# Altium schematic sheet eboardPapyFeederV2.1.SchDoc(eboardPapyFeederV2.1)
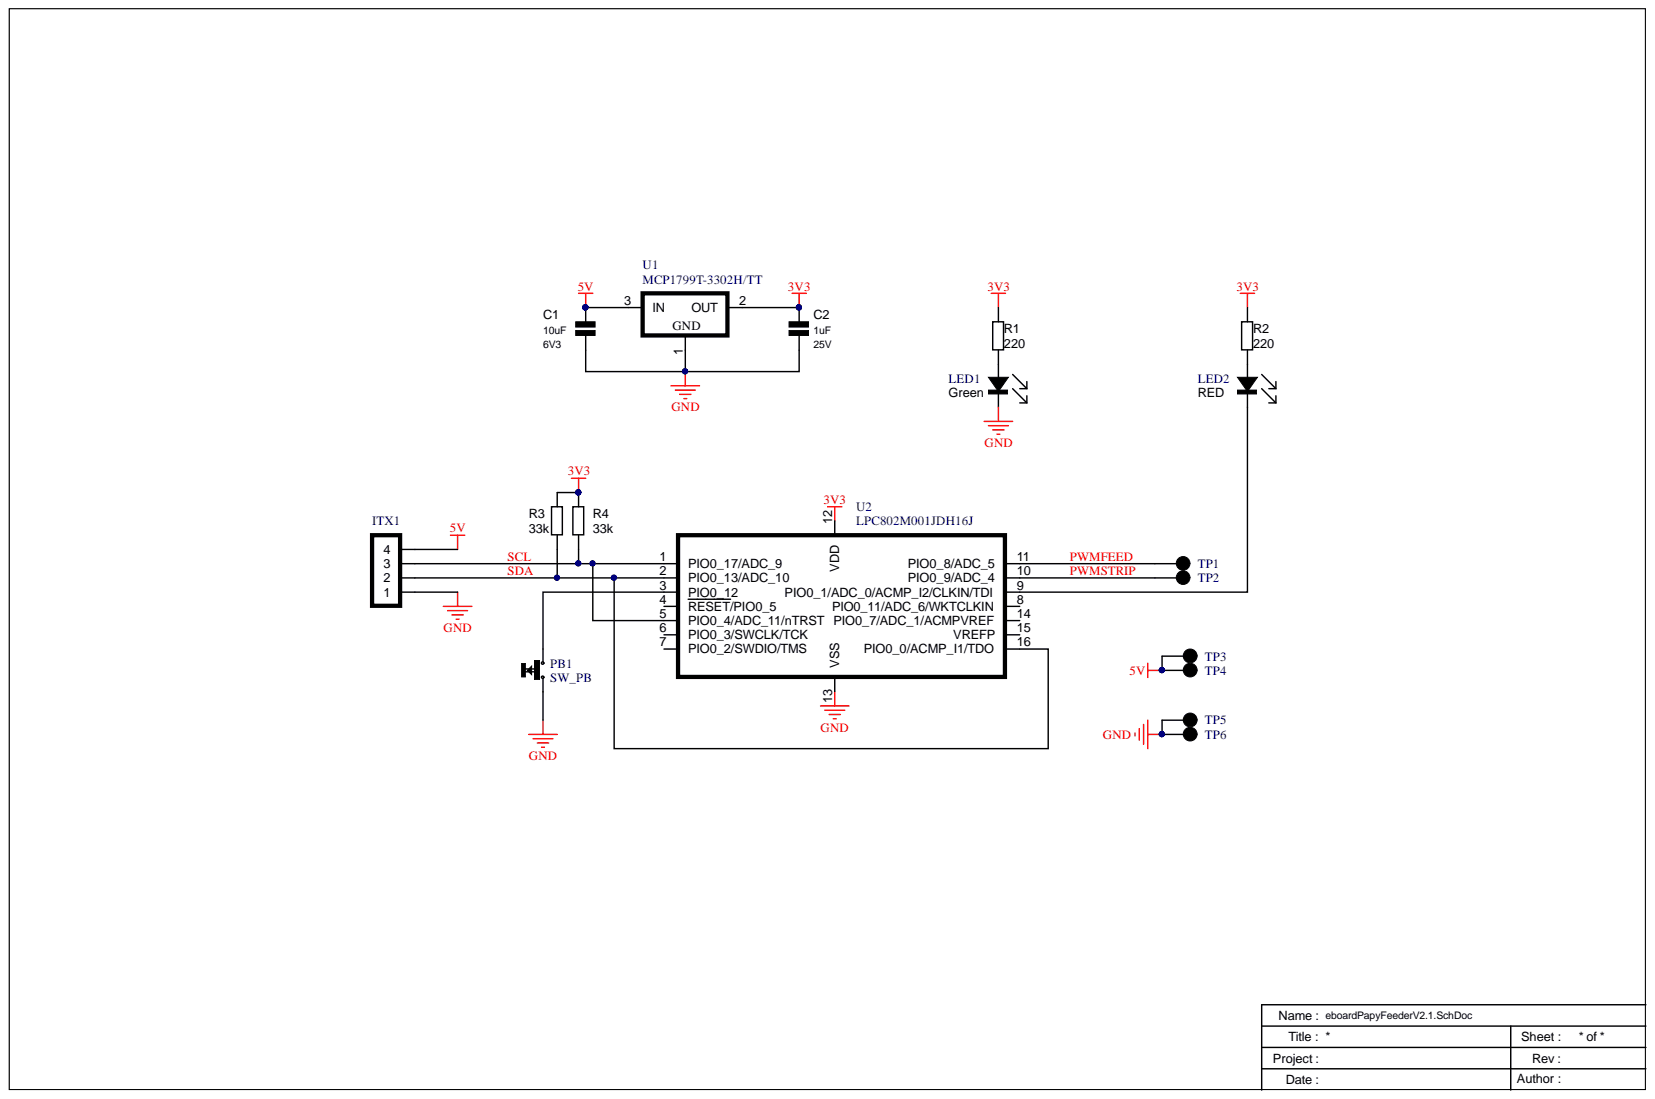
[diagram p0: eboardPapyFeederV2.1.SchDoc]

## Parts
C1  value 10uF  Voltage: 6V3  [0603_CAP]  (hidden comment: CAP)
C2  value 1uF  Voltage: 25V  [0603_CAP]  (hidden comment: CAP)
ITX1  Header 01X04  [HEADER_1X4_2MM_SPRING_01]  (value not drawn)
LED1  Green  [0603_LED]
LED2  RED  [0603_LED]
PB1  SW_PB  [SKRPABE010]
R1  value 220  [0603_RES]  (hidden comment: RES)
R2  value 220  [0603_RES]  (hidden comment: RES)
R3  value 33k  [0603_RES]  (hidden comment: RES)
R4  value 33k  [0603_RES]  (hidden comment: RES)
TP1  TP  [PAD_TOP_1x5_REC]
TP2  TP  [PAD_TOP_1x5_REC]
TP3  TP  [PAD_TOP_1x5_REC]
TP4  TP  [PAD_TOP_1x5_REC]
TP5  TP  [PAD_TOP_1x5_REC]
TP6  TP  [PAD_TOP_1x5_REC]
U1  3P-Fixed_GND_VOUT_VIN  [SOT95P237X112-3N]  (value not drawn)
U2  LPC802M001JDH16J  [SOP65P640X110-16M]

## Nets
3V3: C2.1 R1.2 R2.2 R3.2 R4.2 U1.2 U2.12(VDD)
5V: C1.1 ITX1.4 TP3.1 TP4.1 U1.3
GND: C1.2 C2.2 ITX1.1 LED1.2 PB1.1 TP5.1 TP6.1 U1.1 U2.13(VSS)
PWMFEED: TP1.1 U2.11
PWMSTRIP: TP2.1 U2.10
SCL: ITX1.3 R4.1 U2.1 U2.5
SDA: ITX1.2 R3.1 U2.2 U2.16
NetLED1_1: LED1.1 R1.1
NetLED2_1: LED2.1 R2.1
NetLED2_2: LED2.2 U2.9
NetPB1_2: PB1.2 U2.3

Not connected: U2 4(RESET/PIO0_5) 6 7 8 14 15(VREFP)

## Library data of the parts
C1 = GRM188R60J106ME84J: CAP CER 10UF 6V3 X5R 0603; Manufacturer: Murata Electronics North America; Tolerance: 20,00Â %; Database Table Name: Capacitor#csv; Temperature Coefficient: X5R; Technologie: CER; Supplier Part Number 1: 81-GRM188R60J106ME4J; Supplier 1: Mouser; Series: GRM; Part Name: GRM188R60J106ME84J; Operating Temperature: -55Â°C ~ 85Â°C; Manufacturer Part Number: GRM188R60J106ME84J; Library Name: Database_Library.DbLib; Component Kind: Standard
C2 = CC0603KRX5R8BB105: CAP CER 1uF 25V X5R 0603; Manufacturer: YAGEO; Tolerance: 10.00%; Database Table Name: Capacitor#csv; Temperature Coefficient: X5R; Technologie: CER; Supplier Part Number 1: 603-CC603KRX5R8BB105; Supplier 1: Mouser; Series: CC; Part Status: Active; Part Name: CC0603KRX5R8BB105; Operating Temperature: -55Â°C ~ 85Â°C; Manufacturer Part Number: CC0603KRX5R8BB105; Library Name: Database_Library.DbLib; Component Kind: Standard
ITX1 = 472861001: 4POS spring connector; Manufacturer: MOLEX; Voltage Rated: 200V*; Pitch: 2mm; Number of Positions: 4; Database Table Name: Connector#csv; Current Rating: 1A; Connector Type: NA; Supplier Part Number 1: WM14329CT-ND; Supplier 1: Digi-Key; Series: 47286; Part Name: 472861001; Operating Temperature: -20Â° to +70Â°C; Number of Rows: 1; Mounting Type: SMD; Manufacturer Part Number: 472861001; Library Name: Database_Library.DbLib; Component Kind: Standard
LED1 = 599-0081-007F: LED Green 0603; Manufacturer: Dialight; Wavelength: 525nm; Forward  Voltage: 3.1V; Forward  Current: 20mA; Database Table Name: LED#csv; Supplier Part Number 1: 645-599-0081-007F; Supplier 1: Mouser; Part Name: 599-0081-007F; Operating Temperature: -40°C to 100°C; Manufacturer Part Number: 599-0081-007F; Library Name: Database_Library.DbLib; Component Kind: Standard; Color: Green
LED2 = 599-0010-007F: LED RED 0603; Manufacturer: Dialight; Wavelength: 633nm; Forward  Voltage: 2.1V; Forward  Current: 20mA; Database Table Name: LED#csv; Supplier Part Number 1: 645-599-0010-007F; Supplier 1: Mouser; Part Name: 599-0010-007F; Operating Temperature: -40°C to 100°C; Manufacturer Part Number: 599-0010-007F; Library Name: Database_Library.DbLib; Component Kind: Standard; Color: RED
PB1 = SKRPABE010: Mini momentary push button; Manufacturer: ALPS; Voltage Rated: 16VDC; Database Table Name: Switch#csv; Current Rating: 50mA; Connector Type: PB; Supplier Part Number 1: 688-SKRPAB; Supplier 1: Mouser; Part Name: SKRPABE010; Operating Temperature: -40°C to 90°C; Mounting Type: SMD; Manufacturer Part Number: SKRPABE010; Library Name: Database_Library.DbLib; Component Kind: Standard
R1 R2 = ERJ-3EKF2200V: RES SMD 220 OHM 1% 1/10W 0603; Manufacturer: Panasonic Electronic Components; Tolerance: ï¿½1%; Temperature Coefficient: ï¿½100ppm/ï¿½C; Power: 0.1W, 1/10W; Database Table Name: Resistor#csv; Supplier Part Number 1: P220HCT-ND; Supplier 1: Digi-Key; Series: ERJ; Part Status: Active; Part Name: ERJ-3EKF2200V; Operating Temperature: -55ï¿½C ~ 155ï¿½C; Manufacturer Part Number: ERJ-3EKF2200V; Library Name: Database_Library.DbLib; Datasheets: http://industrial.panasonic.com/www-cgi/jvcr13pz.cgi?E+PZ+3+AOA0002+ERJ3EKF2200V+7+WW; Composition: Thick Film; Component Kind: Standard
R3 R4 = ERJ-3EKF3302V: RES SMD 33K OHM 1% 1/10W 0603; Manufacturer: Panasonic Electronic Components; Tolerance: ï¿½1%; Temperature Coefficient: ï¿½100ppm/ï¿½C; Power: 0.1W, 1/10W; Database Table Name: Resistor#csv; Supplier Part Number 1: P33.0KHCT-ND; Supplier 1: Digi-Key; Series: ERJ; Part Status: Active; Part Name: ERJ-3EKF3302V; Operating Temperature: -55ï¿½C ~ 155ï¿½C; Manufacturer Part Number: ERJ-3EKF3302V; Library Name: Database_Library.DbLib; Datasheets: http://industrial.panasonic.com/www-cgi/jvcr13pz.cgi?E+PZ+3+AOA0002+ERJ3EKF3302V+7+WW; Composition: Thick Film; Component Kind: Standard
TP1-TP6 = PAD_1x5_REC: Manufacturer Part Number: None; Database Table Name: Others#csv; Supplier Part Number 1: None; Supplier 1: None; Part Name: PAD_1x5_REC; Manufacturer: None; Library Name: Database_Library.DbLib; Description: PAD_1x5_REC; Component Kind: Standard
U1 = MCP1799T-3302H/TT: LDO 3V3 80mA; Manufacturer: MICROCHIP; Voltage Accuracy: Â±2%; Polarity: Positive; Output Voltage: 3.3V; Max Input Voltage: 45V; Dropout Voltage: 1.2V; Database Table Name: Regulator_Linear#csv; Type: LDO; Supplier Part Number 1: 579-CP1799T-3302H/TT; Supplier 1: Mouser; Part Name: MCP1799T-3302H/TT; Operating Temperature: -40ï¿½C to 150ï¿½C; Max Output Current: 80mA; Manufacturer Part Number: MCP1799T-3302H/TT; Library Name: Database_Library.DbLib; Component Kind: Standard
U2 = LPC802M001JDH16J: ARM Cortex M0+; Manufacturer: NXP; Voltage: 3.3V; Database Table Name: IC#csv; Type: Processor; Supplier Part Number 1: 771-LPC802M001JDH16J; Supplier 1: Mouser; Part Name: LPC802M001JDH16J; Manufacturer Part Number: LPC802M001JDH16J; Library Name: Database_Library.DbLib; Component Kind: Standard
